# Altium schematic sheet IO.SchDoc
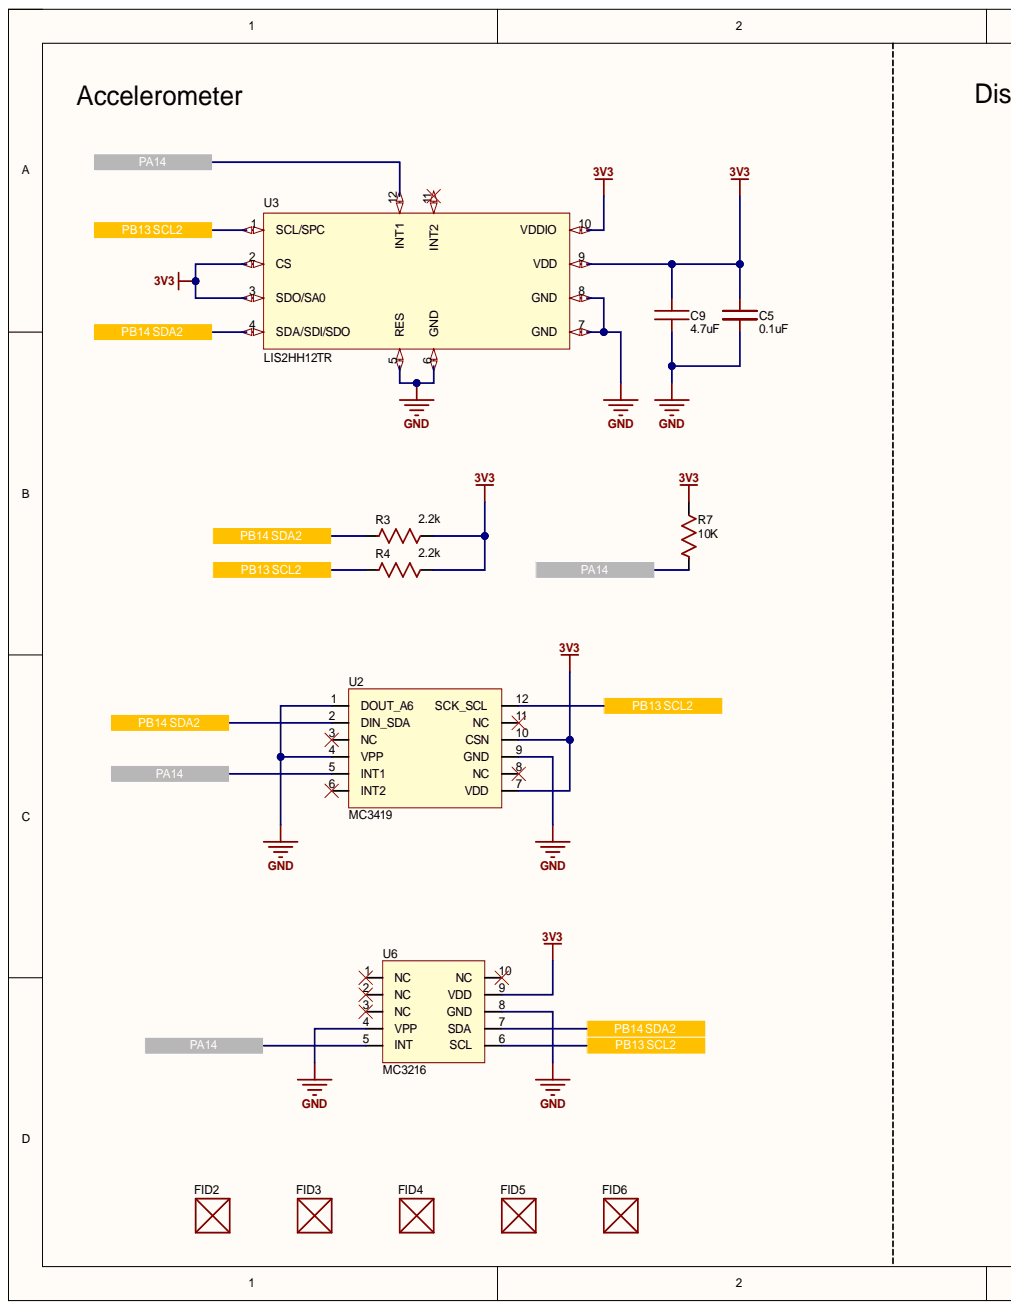
[diagram p0: IO.SchDoc, left]
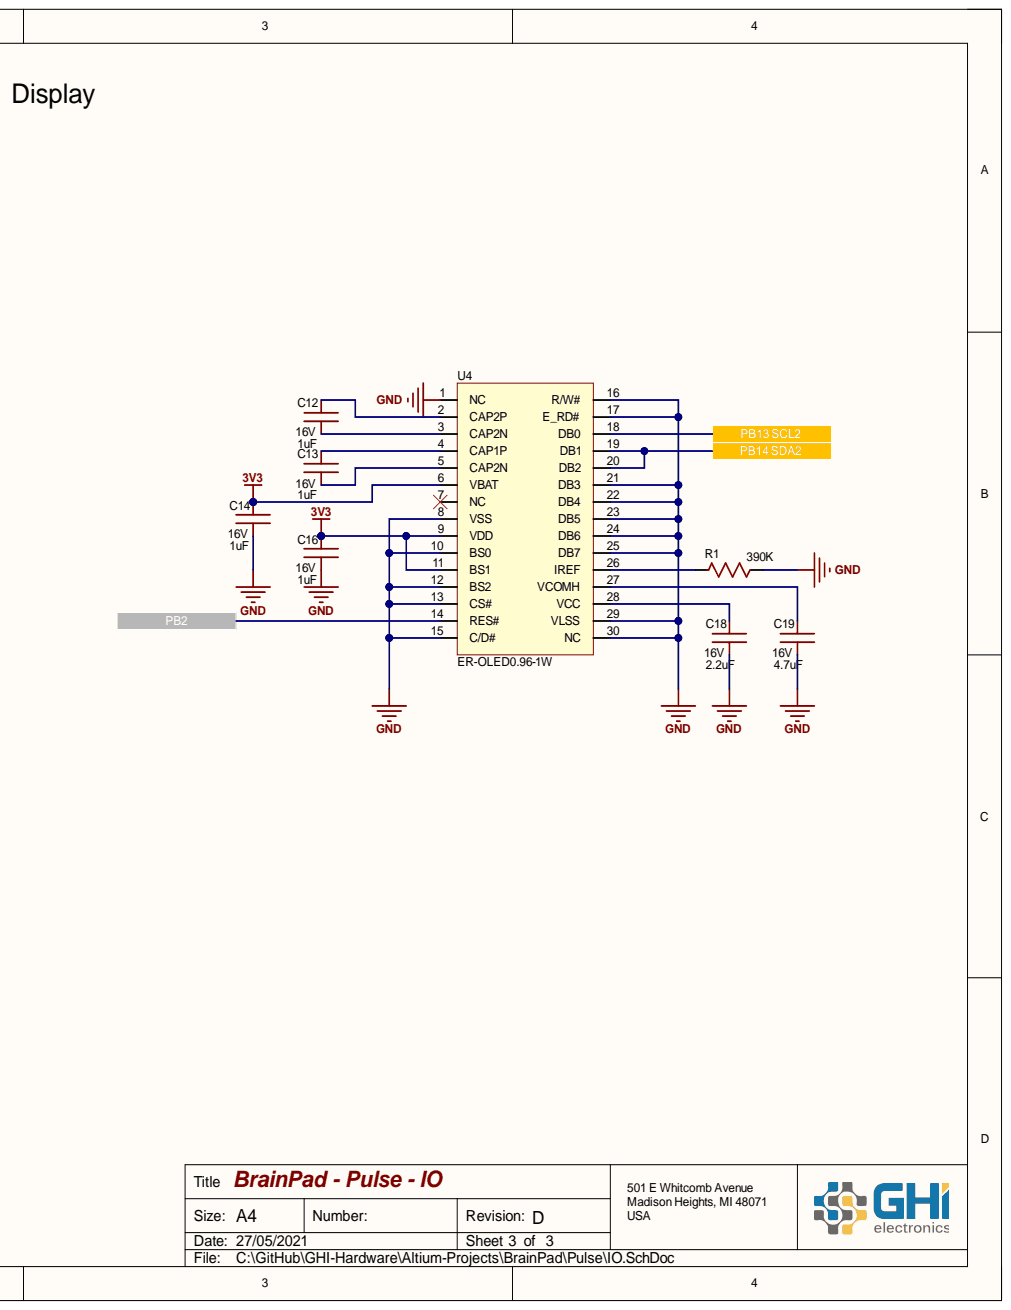
[diagram p1: IO.SchDoc, right]

## Parts
C5  value 0.1uF  Capacitance: 0.1uF  [C0402]  (hidden comment: 0402 Cap 0.1uF)
C9  value 4.7uF  Capacitance: 4.7uF  [C0603 (1608 Metric)]  (hidden comment: 0603 Cap 4.7uF)
C12  value 1uF  Capacitance: 1uF  Voltage: 16V  [C0805 L]  (hidden comment: 0805 Cap 1uF)
C13  value 1uF  Capacitance: 1uF  Voltage: 16V  [C0805 L]  (hidden comment: 0805 Cap 1uF)
C14  value 1uF  Capacitance: 1uF  Voltage: 16V  [C0805 L]  (hidden comment: 0805 Cap 1uF)
C16  value 1uF  Capacitance: 1uF  Voltage: 16V  [C0805 L]  (hidden comment: 0805 Cap 1uF)
C18  value 2.2uF  Capacitance: 2.2uF  Voltage: 16V  [C0805 L]  (hidden comment: 0805 Cap 2.2uF)
C19  value 4.7uF  Capacitance: 4.7uF  Voltage: 16V  [C0805 L]  (hidden comment: 0805 Cap 4.7uF)
FID2  Fiducial 40 Mil  [Fiducial 40 Mil]  (value not drawn)
FID3  Fiducial 40 Mil  [Fiducial 40 Mil]  (value not drawn)
FID4  Fiducial 40 Mil  [Fiducial 40 Mil]  (value not drawn)
FID5  Fiducial 40 Mil  [Fiducial 40 Mil]  (value not drawn)
FID6  Fiducial 40 Mil  [Fiducial 40 Mil]  (value not drawn)
R1  value 390K  Resistance: 390K  [R0603 (1608 Metric)]  (hidden comment: 0603 Res 390K)
R3  value 2.2k  Resistance: 2.2k  [R0402]  (hidden comment: 0402 Res 2.2k)
R4  value 2.2k  Resistance: 2.2k  [R0402]  (hidden comment: 0402 Res 2.2k)
R7  value 10K  Resistance: 10K  [R0402]  (hidden comment: 0402 Res 10K)
U2  MC3419  [LGA-12(ST)]
U3  value LIS2HH12TR  [LGA-12(ST)]
U4  ER-OLED0.96-1W  [ER-OLED0.96-1]
U6  MC3216  [LGA-10-3X3]

## Ports
PA14, PB2, PB13 SCL2, PB14 SDA2

## Nets
3V3: C5.2 C9.2 C14.2 C16.2 R3.2 R4.2 R7.2 U2.7(VDD) U2.10(CSN) U3.2(CS) U3.3(SDO/SA0) U3.9(VDD) U3.10(VDDIO) U4.6(VBAT) U4.9(VDD) U4.11(BS1) U6.9(VDD)
GND: C5.1 C9.1 C14.1 C16.1 C18.1 C19.1 R1.2 U2.1(DOUT_A6) U2.4(VPP) U2.9(GND) U3.5(RES) U3.6(GND) U3.7(GND) U3.8(GND) U4.1(NC) U4.8(VSS) U4.10(BS0) U4.12(BS2) U4.13(CS#) U4.15(C/D#) U4.16(R/W#) U4.17(E_RD#) U4.21(DB3) U4.22(DB4) U4.23(DB5) U4.24(DB6) U4.25(DB7) U4.29(VLSS) U4.30(NC) U6.4(VPP) U6.8(GND)
PA14: R7.1 U2.5(INT1) U3.12(INT1) U6.5(INT) ; port PA14
PB2: U4.14(RES#) ; port PB2
PB13 SCL2: R4.1 U2.12(SCK_SCL) U3.1(SCL/SPC) U4.18(DB0) U6.6(SCL) ; port PB13 SCL2
PB14 SDA2: R3.1 U2.2(DIN_SDA) U3.4(SDA/SDI/SDO) U4.19(DB1) U4.20(DB2) U6.7(SDA) ; port PB14 SDA2
NetC12_1: C12.1 U4.3(CAP2N)
NetC12_2: C12.2 U4.2(CAP2P)
NetC13_1: C13.1 U4.5(CAP2N)
NetC13_2: C13.2 U4.4(CAP1P)
NetC18_2: C18.2 U4.28(VCC)
NetC19_2: C19.2 U4.27(VCOMH)
NetR1_1: R1.1 U4.26(IREF)

Not connected: U2 3(NC) 6(INT2) 8(NC) 11(NC); U3 11(INT2); U4 7(NC); U6 1(NC) 2(NC) 3(NC) 10(NC)

## Library data of the parts
C5 = 0402 Cap 0.1uF: 0.1uF ±10% 16V X7R 0402 Multilayer Ceramic Capacitors MLCC - SMD/SMT RoHS; Mfr.Part #: CC0402KRX7R7BB104; Category: Ceramic; Component Kind: Standard; LCSC Part #: C60474; Package: 0402; Tolerance: ±10%; Voltage: 16V; Library Name: GHI-Passives.SchLib
C9 = 0603 Cap 4.7uF: 4.7uF ±10% 25V X5R 0603 Multilayer Ceramic Capacitors MLCC - SMD/SMT RoHS; Manufacturer: Samsung Electro-Mechanics; Mfr.Part #: CL10A475KA8NQNC; Category: Ceramic; Component Kind: Standard; LCSC Part #: C69335; Package: 0603; Tolerance: ±10%; Voltage: 25V; Library Name: GHI-Passives.SchLib
C12-C14 C16 = 0805 Cap 10uF: 1uF ±10% 25V X5R 0805 Multilayer Ceramic Capacitors MLCC - SMD/SMT RoHS; Manufacturer: YAGEO; Category: Ceramic; Component Kind: Standard; Package: 0805; Tolerance: ±10%; Library Name: GHI-Passives.SchLib
C18 = 0805 Cap 10uF: 2.2uF ±10% 25V X5R 0805 Multilayer Ceramic Capacitors MLCC - SMD/SMT RoHS; Manufacturer: YAGEO; Category: Ceramic; Component Kind: Standard; Package: 0805; Tolerance: ±10%; Library Name: GHI-Passives.SchLib
C19 = 0805 Cap 10uF: 4.7uF ±10% 25V X5R 0805 Multilayer Ceramic Capacitors MLCC - SMD/SMT RoHS; Manufacturer: YAGEO; Category: Ceramic; Component Kind: Standard; Package: 0805; Tolerance: ±10%; Library Name: GHI-Passives.SchLib
R1 = 0603 Res 0: ±5% 1/10W 0603 Chip Resistor - Surface Mount RoHS; Manufacturer: YAGEO; Voltage Rating: *V; Component Kind: Standard; Package: 0603; Power Rating: 1/10W; Resistance Tolerance: ±5%; Library Name: GHI-Passives.SchLib
R3 R4 = 0402 Res 2.2k: 2.2K ±1% 1/16W ±100ppm/℃ 0402 Chip Resistor - Surface Mount RoHS; Manufacturer: YAGEO; Mfr.Part #: RC0402FR-072K2L; Voltage Rating: *V; Component Kind: Standard; LCSC Part #: C114762; Package: 0402; Power Rating: 1/16W; Resistance Tolerance: ±1%; Library Name: GHI-Passives.SchLib
R7 = 0402 Res 10K: 10K ±1% 1/16W ±100ppm/℃ 0402 Chip Resistor - Surface Mount RoHS; Manufacturer: YAGEO; Mfr.Part #: RC0402FR-0710KL; Voltage Rating: *V; Component Kind: Standard; LCSC Part #: C60490; Package: 0402; Power Rating: 1/16W; Resistance Tolerance: ±1%; Library Name: GHI-Passives.SchLib
U3 = LIS2HH12: ST - MEMS digital output motion sensor: ultra-low-power high-performance 3-axis "pico" accelerometer; Component Kind: Standard; Package: LGA12; Library Name: GHI.SchLib
FID2-FID6 = Fiducial 40 Mil: Component Kind: Standard; Library Name: GHI-Misc.SchLib
U2 = MC3419: Component Kind: Standard; Library Name: GHI-Integrated Circuits.SchLib
U4 = ER-OLED0.96-1W: Component Kind: Standard; Library Name: GHI-Opto.SchLib
U6 = MC3216: Component Kind: Standard; Library Name: GHI-Integrated Circuits.SchLib
